# Altium schematic sheet Sheetduino32I.SchDoc
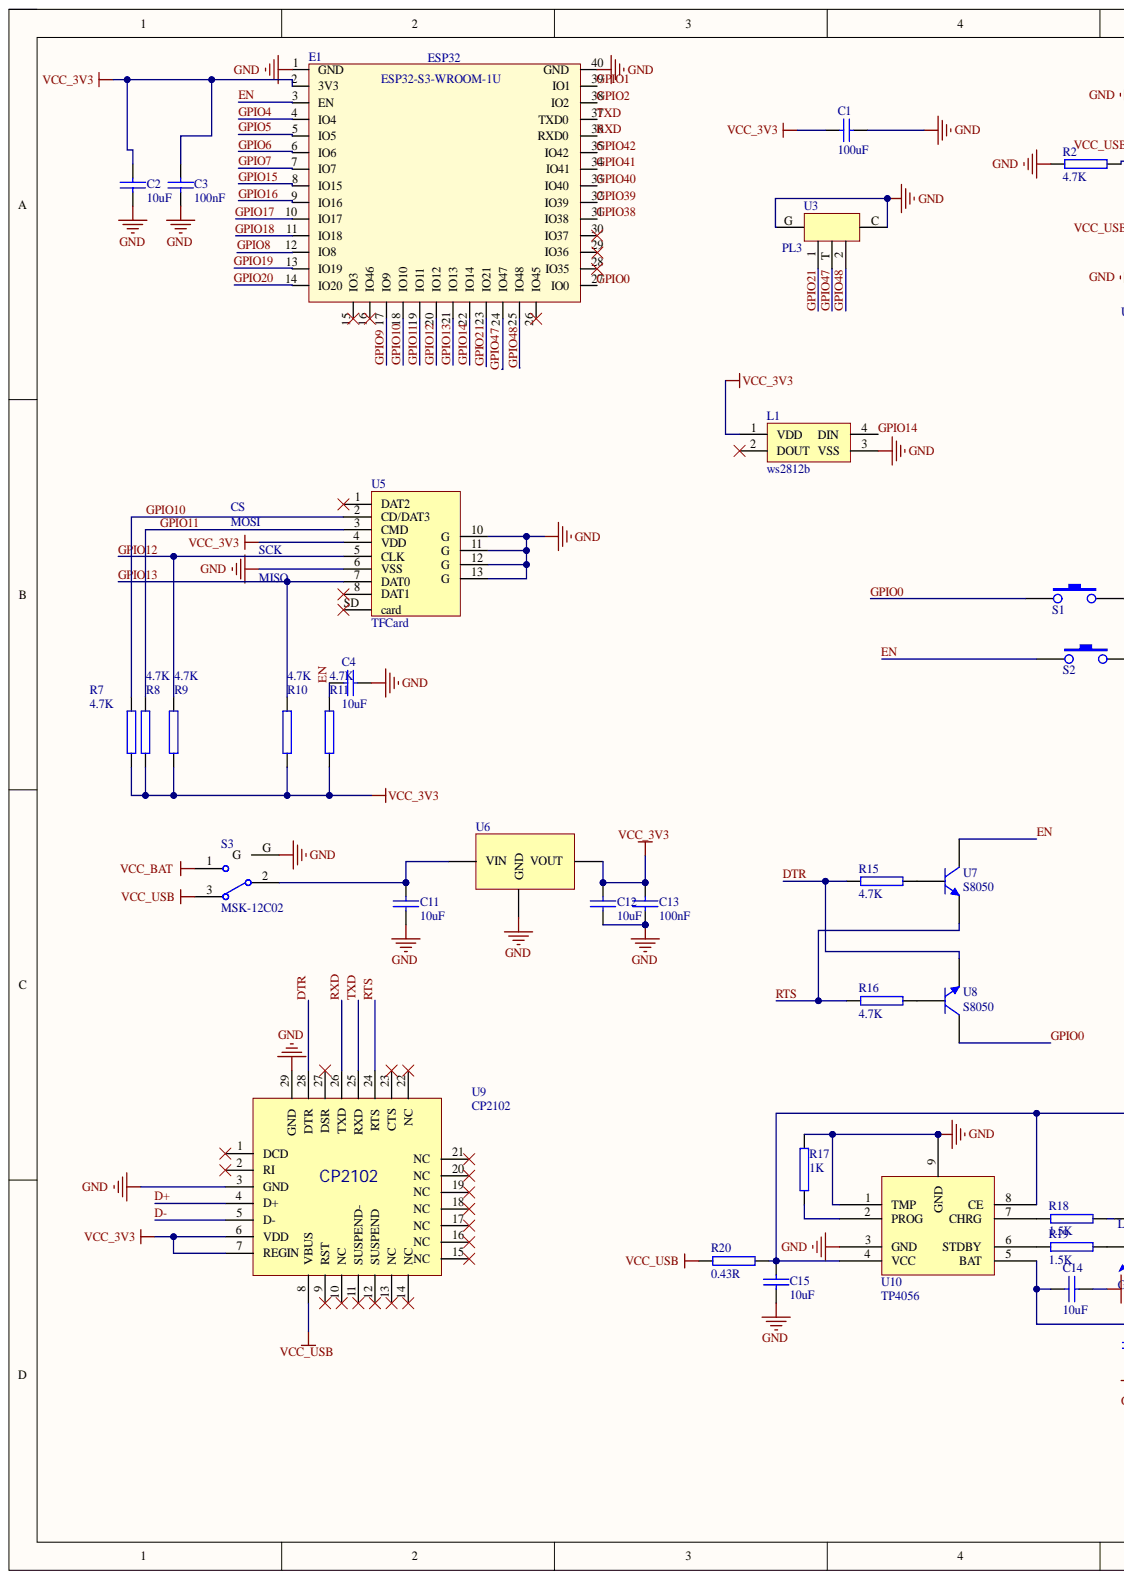
[diagram p0: Sheetduino32I.SchDoc, left]
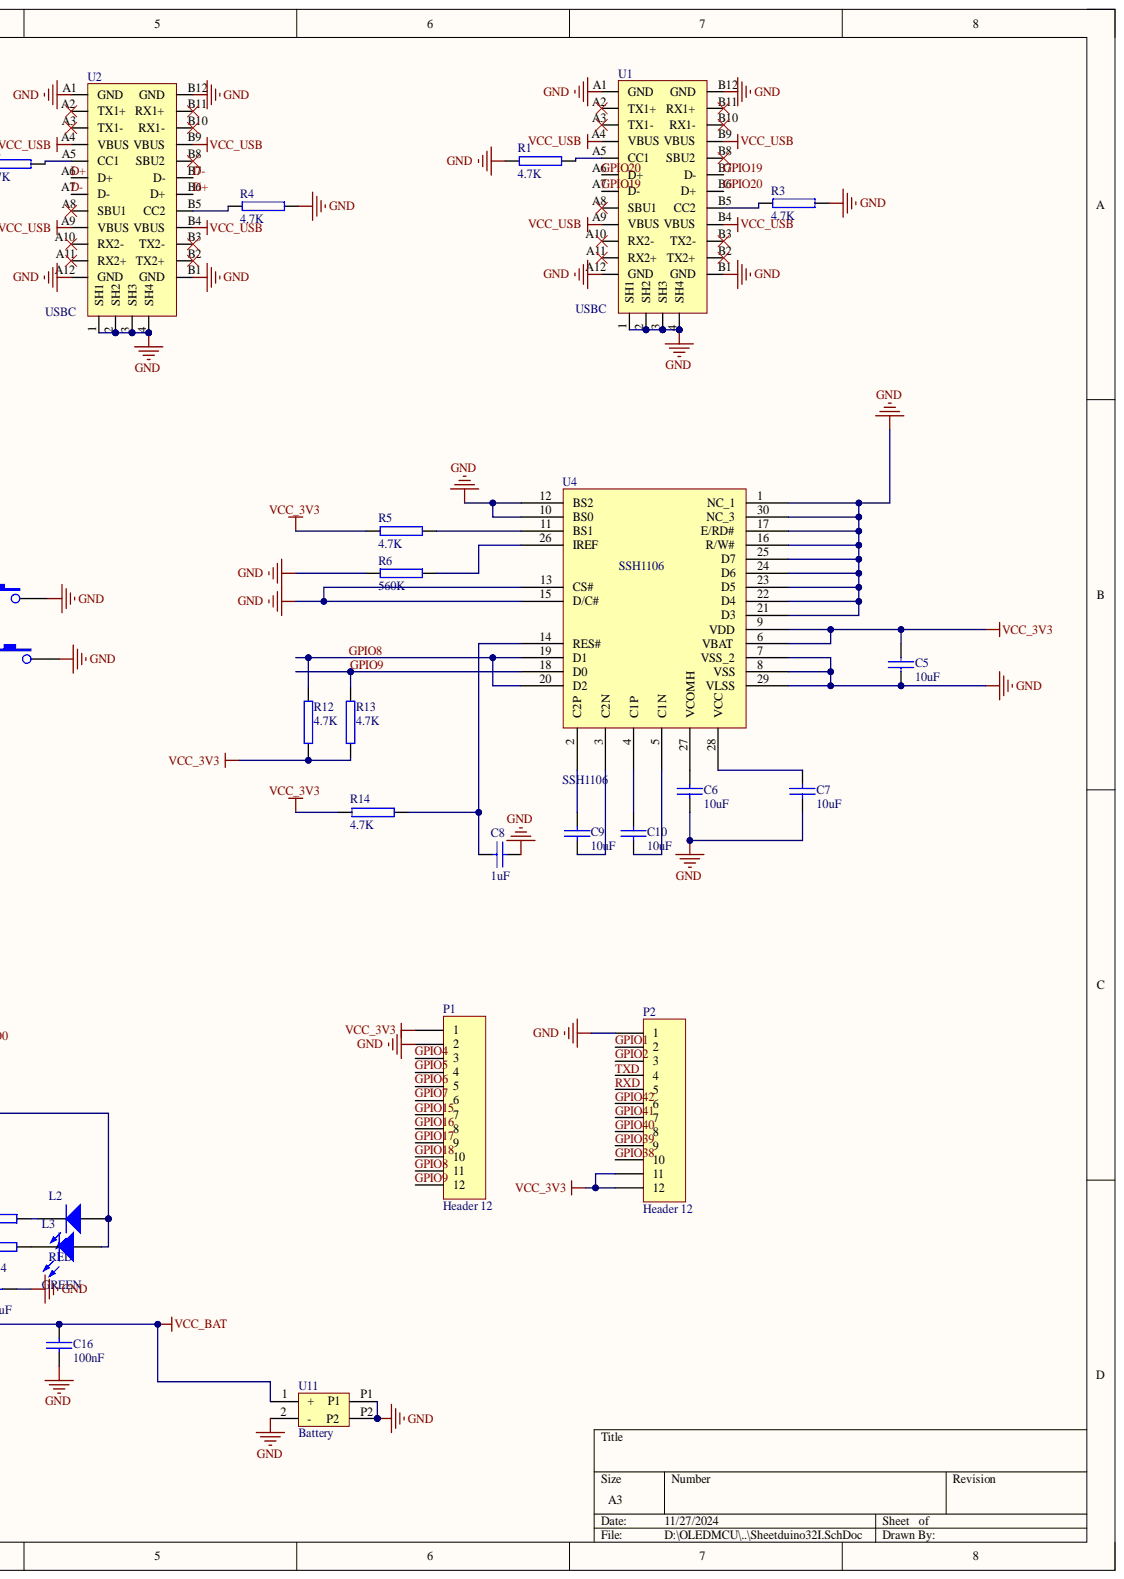
[diagram p1: Sheetduino32I.SchDoc, right]

## Parts
C1  100uF  [C3528]
C2  10uF  [C0603]
C3  100nF  [C0603]
C4  10uF  [C0603]
C5  10uF  [C0603]
C6  10uF  [C0603]
C7  10uF  [C0603]
C8  1uF  [C0603]
C9  10uF  [C0603]
C10  10uF  [C0603]
C11  10uF  [C0603]
C12  10uF  [C0603]
C13  100nF  [C0603]
C14  10uF  [C0603]
C15  10uF  [C0603]
C16  100nF  [C0603]
E1  ESP32  [ESP32-S3-WROOM-1U]
L1  ws2812b  [ws2812b]
L2  RED  [LED]
L3  GREEN  [LED]
P1  Header 12  [HDR1X12]
P2  Header 12  [HDR1X12]
R1  4.7K  [R0603]
R2  4.7K  [R0603]
R3  4.7K  [R0603]
R4  4.7K  [R0603]
R5  4.7K  [R0603]
R6  560K  [R0603]
R7  4.7K  [R0603]
R8  4.7K  [R0603]
R9  4.7K  [R0603]
R10  4.7K  [R0603]
R11  4.7K  [R0603]
R12  4.7K  [R0603]
R13  4.7K  [R0603]
R14  4.7K  [R0603]
R15  4.7K  [R0603]
R16  4.7K  [R0603]
R17  1K  [R0603]
R18  1.5K  [R0603]
R19  1.5K  [R0603]
R20  0.43R  [R0603]
S1  贴片按钮  [switch]  (value not drawn)
S2  贴片按钮  [switch]  (value not drawn)
S3  MSK-12C02  [Switch_MSK-12C02]
U1  USBC  [USB-C_TOP]
U2  USBC  [USB-C_TOP]
U3  PL3  [PL3]
U4  SSH1106  [SSH1106]
U5  TFCard  [TFCard]
U6  LM39100  [LM39100]  (value not drawn)
U7  S8050  [s8050]
U8  S8050  [s8050]
U9  CP2102  [cp2102]
U10  TP4056  [TP4056]
U11  Battery  [S2B-PH-SM4-TB]

## Nets
GND: C1.2 C2.1 C3.1 C4.1 C5.2 C6.2 C7.2 C8.2 C11.2 C12.2 C13.2 C14.2 C15.1 C16.1 E1.1(GND) E1.40(GND) L1.3(VSS) P1.2 P2.1 R1.2 R2.2 R3.1 R4.1 R6.1 R17.2 S1.2 S2.2 S3.G U1.1(SH1) U1.2(SH2) U1.3(SH3) U1.4(SH4) U1.A1(GND) U1.A12(GND) U1.B1(GND) U1.B12(GND) U2.1(SH1) U2.2(SH2) U2.3(SH3) U2.4(SH4) U2.A1(GND) U2.A12(GND) U2.B1(GND) U2.B12(GND) U3.C U3.G U4.1(NC_1) U4.7(VSS_2) U4.8(VSS) U4.10(BS0) U4.12(BS2) U4.13(CS#) U4.15(D/C#) U4.16(R/W#) U4.17(E/RD#) U4.21(D3) U4.22(D4) U4.23(D5) U4.24(D6) U4.25(D7) U4.29(VLSS) U4.30(NC_3) U5.6(VSS) U5.10(G) U5.11(G) U5.12(G) U5.13(G) U6.2 U9.3(GND) U9.29(GND) U10.1(TMP) U10.3(GND) U10.9(GND) U11.2(-) U11.P1 U11.P2
VCC_3V3: C1.1 C2.2 C3.2 C5.1 C12.1 C13.1 E1.2(3V3) L1.1(VDD) P1.1 P2.11 P2.12 R5.1 R7.1 R8.1 R9.1 R10.1 R11.1 R12.1 R13.1 R14.1 U4.6(VBAT) U4.9(VDD) U5.4(VDD) U6.3 U9.6(VDD) U9.7(REGIN)
VCC_BAT: C14.1 C16.2 S3.1 U10.5(BAT) U11.1(+)
VCC_USB: R20.1 S3.3 U1.A4(VBUS) U1.A9(VBUS) U1.B4(VBUS) U1.B9(VBUS) U2.A4(VBUS) U2.A9(VBUS) U2.B4(VBUS) U2.B9(VBUS) U9.8(VBUS)
D+: U2.A6(D+) U2.B6(D+) U9.4(D+)
D-: U2.A7(D-) U2.B7(D-) U9.5(D-)
DTR: R15.1 U8.2 U9.28(DTR)
EN: C4.2 E1.3(EN) R11.2 S2.1 U7.3
GPIO0: E1.27(IO0) S1.1 U8.3
GPIO1: E1.39(IO1) P2.2
GPIO2: E1.38(IO2) P2.3
GPIO4: E1.4(IO4) P1.3
GPIO5: E1.5(IO5) P1.4
GPIO6: E1.6(IO6) P1.5
GPIO7: E1.7(IO7) P1.6
GPIO8: E1.12(IO8) P1.11 R12.2 U4.19(D1) U4.20(D2)
GPIO9: E1.17(IO9) P1.12 R13.2 U4.18(D0)
GPIO10: E1.18(IO10) R7.2 U5.2(CD/DAT3)
GPIO11: E1.19(IO11) R8.2 U5.3(CMD)
GPIO12: E1.20(IO12) R9.2 U5.5(CLK)
GPIO13: E1.21(IO13) R10.2 U5.7(DAT0)
GPIO14: E1.22(IO14) L1.4(DIN)
GPIO15: E1.8(IO15) P1.7
GPIO16: E1.9(IO16) P1.8
GPIO17: E1.10(IO17) P1.9
GPIO18: E1.11(IO18) P1.10
GPIO19: E1.13(IO19) U1.A7(D-) U1.B7(D-)
GPIO20: E1.14(IO20) U1.A6(D+) U1.B6(D+)
GPIO21: E1.23(IO21) U3.1
GPIO38: E1.31(IO38) P2.10
GPIO39: E1.32(IO39) P2.9
GPIO40: E1.33(IO40) P2.8
GPIO41: E1.34(IO41) P2.7
GPIO42: E1.35(IO42) P2.6
GPIO47: E1.24(IO47) U3.T
GPIO48: E1.25(IO48) U3.2
RTS: R16.1 U7.2 U9.24(RTS)
RXD: E1.36(RXD0) P2.5 U9.26(TXD)
TXD: E1.37(TXD0) P2.4 U9.25(RXD)
NetC6_1: C6.1 U4.27(VCOMH)
NetC7_1: C7.1 U4.28(VCC)
NetC8_1: C8.1 R14.2 U4.14(RES#)
NetC9_1: C9.1 U4.2(C2P)
NetC9_2: C9.2 U4.3(C2N)
NetC10_1: C10.1 U4.4(C1P)
NetC10_2: C10.2 U4.5(C1N)
NetC11_1: C11.1 S3.2 U6.1
NetC15_2: C15.2 L2.2 L3.2 R20.2 U10.4(VCC) U10.8(CE)
NetL2_1: L2.1 R18.2
NetL3_1: L3.1 R19.2
NetR1_1: R1.1 U1.A5(CC1)
NetR2_1: R2.1 U2.A5(CC1)
NetR3_2: R3.2 U1.B5(CC2)
NetR4_2: R4.2 U2.B5(CC2)
NetR5_2: R5.2 U4.11(BS1)
NetR6_2: R6.2 U4.26(IREF)
NetR15_2: R15.2 U7.1
NetR16_2: R16.2 U8.1
NetR17_1: R17.1 U10.2(PROG)
NetR18_1: R18.1 U10.7(CHRG)
NetR19_1: R19.1 U10.6(STDBY)

Not connected: E1 15(IO3) 16(IO46) 26(IO45) 28(IO35) 29(IO36) 30(IO37); L1 2(DOUT); U1 A2(TX1+) A3(TX1-) A8(SBU1) A10(RX2-) A11(RX2+) B2(TX2+) B3(TX2-) B8(SBU2) B10(RX1-) B11(RX1+); U2 A2(TX1+) A3(TX1-) A8(SBU1) A10(RX2-) A11(RX2+) B2(TX2+) B3(TX2-) B8(SBU2) B10(RX1-) B11(RX1+); U5 1(DAT2) 8(DAT1) SD(card); U9 1(DCD) 2(RI) 9(RST) 10(NC) 11(SUSPEND-) 12(SUSPEND) 13(NC) 14(NC) 15(NC) 16(NC) 17(NC) 18(NC) 19(NC) 20(NC) 21(NC) 22(NC) 23(CTS) 27(DSR)

## Library data of the parts
C1 = Cap Semi: Capacitor 3.5x2.8mm; Component Kind: Standard; LatestRevisionDate: 29-May-2009; LatestRevisionNote: IPC-7351 Footprint Added.; Library Name: Miscellaneous Devices.IntLib; PackageDescription: Chip Capacitor; PackageReference: C1206; Published: 8-Jun-2000; Signal Integrity: Cap Semi; Simulation: CAP; Value: 100uF; Publisher: Altium Limited
C2-C16 = C0603: 贴片电容; Component Kind: Standard; Library Name: Schlib_OLEDMCU.SchLib
E1 = ESP32-S3-WROOM-1U: Component Kind: Standard; Description: ESP32-S3-WROOM-1U; Library Name: Schlib_OLEDMCU.SchLib
L1 = ws2812b: RGB灯珠; Component Kind: Standard; Library Name: Schlib_OLEDMCU.SchLib
L2 L3 = LED: 0603 led; Component Kind: Standard; Library Name: Schlib_OLEDMCU.SchLib
P1 P2 = Header 12: Header, 12-Pin; Component Kind: Standard; LatestRevisionDate: 17-Jul-2002; LatestRevisionNote: Re-released for DXP Platform.; Library Name: Miscellaneous Connectors.IntLib; Signal Integrity: Connector; Publisher: Altium Limited
R1-R19 = R0603: 贴片电阻; Component Kind: Standard; Library Name: Schlib_OLEDMCU.SchLib
R20 = R0603: SMT_0603; Component Kind: Standard; Library Name: Schlib_powershield.SchLib
S1 S2 = Switch: 3*4*2mm开关; Component Kind: Standard; Library Name: Schlib_OLEDMCU.SchLib
S3 = Switch_MSK-12C02: 贴片开关; Component Kind: Standard; Library Name: Schlib_OLEDMCU.SchLib
U1 U2 = USB-C_TOP: Type-C; Component Kind: Standard; Library Name: Schlib_OLEDMCU.SchLib
U3 = PL3: 拨轮开关; Component Kind: Standard; Library Name: Schlib_OLEDMCU.SchLib
U4 = SSH1106: 1.3寸OLED; Component Kind: Standard; Library Name: Schlib_OLEDMCU.SchLib
U5 = TFCard: TF  卡座; Component Kind: Standard; Library Name: Schlib_OLEDMCU.SchLib
U6 = LM39100: 3.3V低压差稳压; Component Kind: Standard; Library Name: Schlib_OLEDMCU.SchLib
U7 U8 = S8050: 三极管; Component Kind: Standard; Library Name: Schlib_OLEDMCU.SchLib
U9 = CP2102: USB转串口芯片; Component Kind: Standard; Library Name: Schlib_OLEDMCU.SchLib
U10 = TP4056: 电源芯片; Component Kind: Standard; Library Name: Schlib_OLEDMCU.SchLib
U11 = S2B-PH-SM4-TB: 贴片2.0mm底座; Component Kind: Standard; Library Name: Schlib_OLEDMCU.SchLib
